# Altium schematic sheet TELECOM.SchDoc(TELECOM)
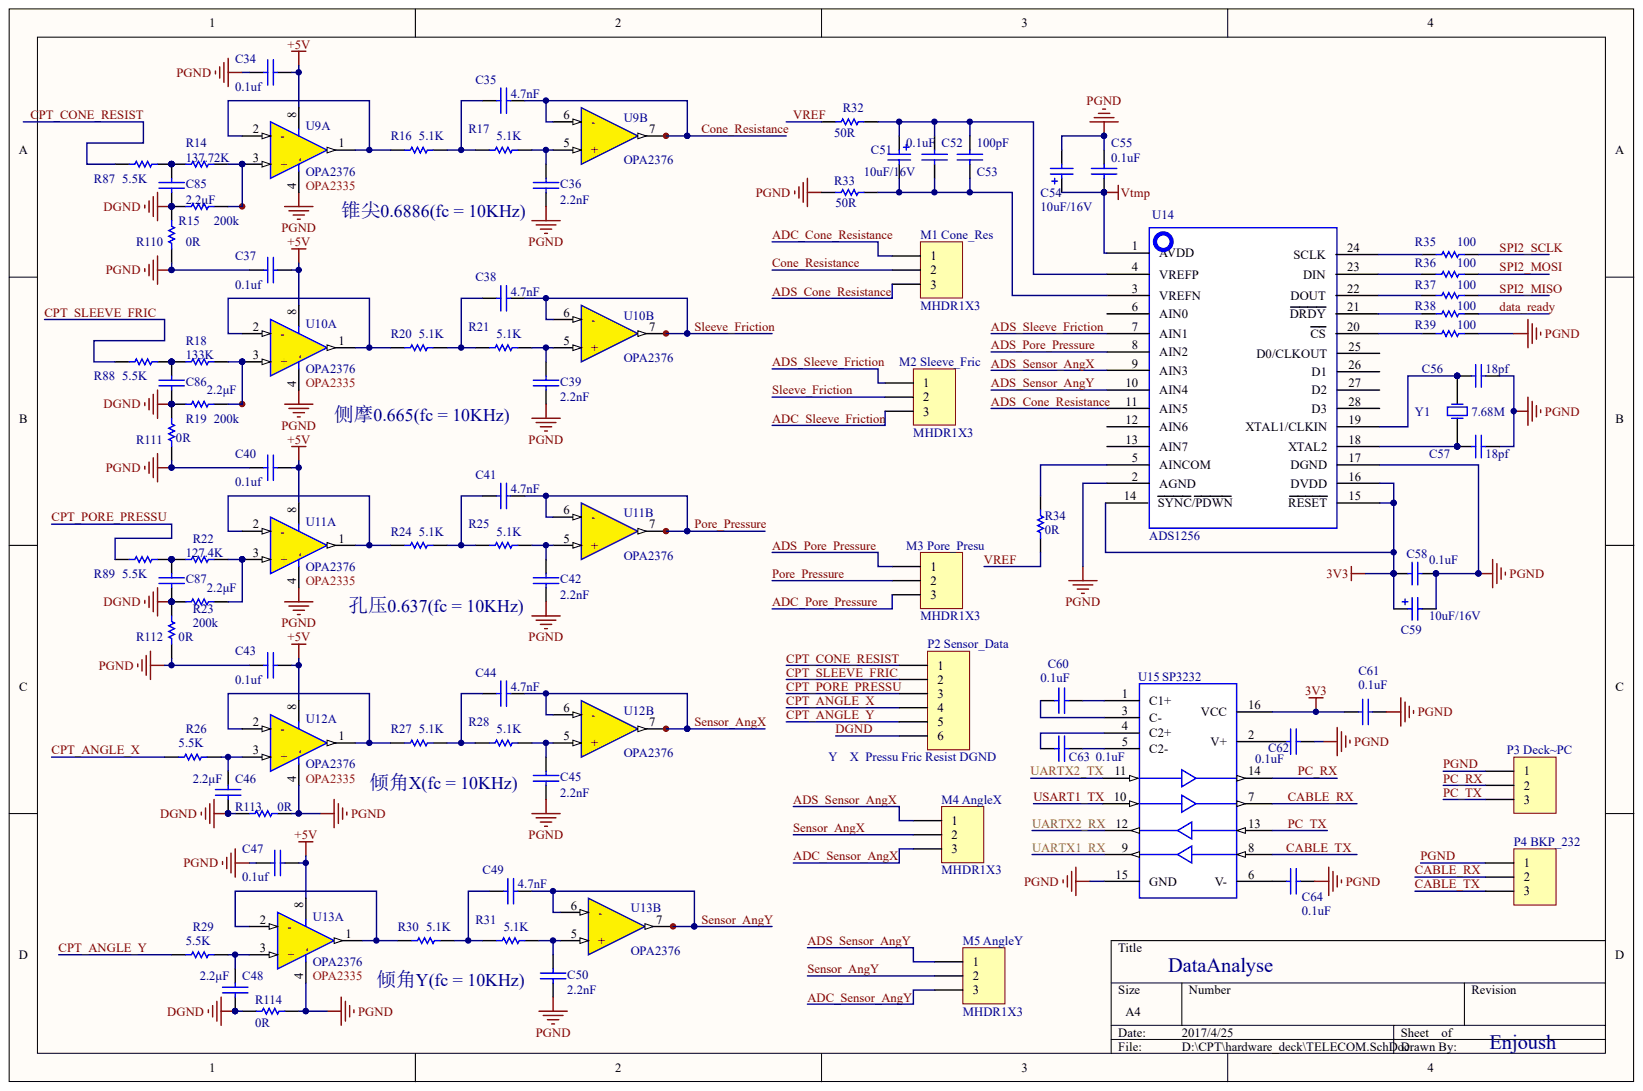
[diagram p0: TELECOM.SchDoc]

## Parts
C34  0.1uf
C35  4.7nF
C36  2.2nF
C37  0.1uf
C38  4.7nF
C39  2.2nF
C40  0.1uf
C41  4.7nF
C42  2.2nF
C43  0.1uf
C44  4.7nF
C45  2.2nF
C46  2.2μF
C47  0.1uf
C48  2.2μF
C49  4.7nF
C50  2.2nF
C51  10uF/16V
C52  0.1uF
C53  100pF
C54  10uF/16V
C55  0.1uF
C56  18pf
C57  18pf
C58  0.1uF
C59  10uF/16V
C60  0.1uF
C61  0.1uF
C62  0.1uF
C63  0.1uF
C64  0.1uF
C85  2.2μF
C86  2.2μF
C87  2.2μF
M1_Cone_Res  MHDR1X3
M2_Sleeve_Fric  MHDR1X3
M3_Pore_Presu  MHDR1X3
M4_AngleX  MHDR1X3
M5_AngleY  MHDR1X3
P2_Sensor_Data  Y    X  Pressu Fric Resist DGND
P3_Deck~PC  (no value)
P4_BKP_232  (no value)
R14  137.72K
R15  200k
R16  5.1K
R17  5.1K
R18  133K
R19  200k
R20  5.1K
R21  5.1K
R22  127.4K
R23  200k
R24  5.1K
R25  5.1K
R26  5.5K
R27  5.1K
R28  5.1K
R29  5.5K
R30  5.1K
R31  5.1K
R32  50R
R33  50R
R34  0R
R35  100
R36  100
R37  100
R38  100
R39  100
R87  5.5K
R88  5.5K
R89  5.5K
R110  0R
R111  0R
R112  0R
R113  0R
R114  0R
U9  OPA2376  (parts A,B)
U10  OPA2376  (parts A,B)
U11  OPA2376  (parts A,B)
U12  OPA2376  (parts A,B)
U13  OPA2376  (parts A,B)
U14  ADS1256
U15  SP3232
Y1  7.68M

## Nets
3V3: C58.1 C59.1 C61.1 U14.14(SYNC/PDWN) U14.15(RESET) U14.16(DVDD) U15.16(VCC)
+5V: C34.1 C37.1 C40.1 C43.1 C47.1 U9.8 U10.8 U11.8 U12.8 U13.8
VTMP: C54.1 C55.1 U14.1(AVDD)
ADC_CONE_RESISTANCE: M1_Cone_Res.1
ADC_PORE_PRESSURE: M3_Pore_Presu.3
ADC_SENSOR_ANGX: M4_AngleX.3
ADC_SENSOR_ANGY: M5_AngleY.3
ADC_SLEEVE_FRICTION: M2_Sleeve_Fric.3
ADS_CONE_RESISTANCE: M1_Cone_Res.3 U14.11(AIN5)
ADS_PORE_PRESSURE: M3_Pore_Presu.1 U14.8(AIN2)
ADS_SENSOR_ANGX: M4_AngleX.1 U14.9(AIN3)
ADS_SENSOR_ANGY: M5_AngleY.1 U14.10(AIN4)
ADS_SLEEVE_FRICTION: M2_Sleeve_Fric.1 U14.7(AIN1)
CABLE_RX: P4_BKP_232.2 U15.7
CABLE_TX: P4_BKP_232.3 U15.8
CONE_RESISTANCE: C35.2 M1_Cone_Res.2 U9.6(-) U9.7
CPT_ANGLE_X: P2_Sensor_Data.4 R26.1
CPT_ANGLE_Y: P2_Sensor_Data.5 R29.1
CPT_CONE_RESIST: P2_Sensor_Data.1 R87.1
CPT_PORE_PRESSU: P2_Sensor_Data.3 R89.1
CPT_SLEEVE_FRIC: P2_Sensor_Data.2 R88.1
DATA_READY: R38.1
DGND: C46.2 C48.2 C85.2 C86.2 C87.2 P2_Sensor_Data.6 R15.1 R19.1 R23.1 R110.2 R111.2 R112.2 R113.1 R114.2
PC_RX: P3_Deck~PC.2 U15.14
PC_TX: P3_Deck~PC.3 U15.13
PGND: C34.2 C36.2 C37.2 C39.2 C40.2 C42.2 C43.2 C45.2 C47.2 C50.2 C54.2 C55.2 C56.1 C57.1 C58.2 C59.2 C61.2 C62.2 C64.2 P3_Deck~PC.1 P4_BKP_232.1 R33.1 R39.1 R110.1 R111.1 R112.1 R113.2 R114.1 U9.4(-) U10.4(-) U11.4(-) U12.4(-) U13.4(-) U14.2(AGND) U14.17(DGND) U15.15(GND)
PORE_PRESSURE: C41.2 M3_Pore_Presu.2 U11.6(-) U11.7
SENSOR_ANGX: C44.2 M4_AngleX.2 U12.6(-) U12.7
SENSOR_ANGY: C49.2 M5_AngleY.2 U13.6(-) U13.7
SLEEVE_FRICTION: C38.2 M2_Sleeve_Fric.2 U10.6(-) U10.7
SPI2_MISO: R37.1
SPI2_MOSI: R36.1
SPI2_SCLK: R35.1
UARTX1_RX: U15.9
UARTX2_RX: U15.12
UARTX2_TX: U15.11
USART1_TX: U15.10
VREF: R32.1 R34.2
NetC35_1: C35.1 R16.2 R17.1
NetC36_1: C36.1 R17.2 U9.5(+)
NetC38_1: C38.1 R20.2 R21.1
NetC39_1: C39.1 R21.2 U10.5(+)
NetC41_1: C41.1 R24.2 R25.1
NetC42_1: C42.1 R25.2 U11.5(+)
NetC44_1: C44.1 R27.2 R28.1
NetC45_1: C45.1 R28.2 U12.5(+)
NetC46_1: C46.1 R26.2 U12.3(+)
NetC48_1: C48.1 R29.2 U13.3(+)
NetC49_1: C49.1 R30.2 R31.1
NetC50_1: C50.1 R31.2 U13.5(+)
NetC51_1: C51.1 C52.2 C53.2 R32.2 U14.4(VREFP)
NetC51_2: C51.2 C52.1 C53.1 R33.2 U14.3(VREFN)
NetC56_2: C56.2 U14.19(XTAL1/CLKIN) Y1.2
NetC57_2: C57.2 U14.18(XTAL2) Y1.1
NetC60_1: C60.1 U15.3(C-)
NetC60_2: C60.2 U15.1(C1+)
NetC62_1: C62.1 U15.2(V+)
NetC63_1: C63.1 U15.4(C2+)
NetC63_2: C63.2 U15.5(C2-)
NetC64_1: C64.1 U15.6(V-)
NetC85_1: C85.1 R14.1 R87.2
NetC86_1: C86.1 R18.1 R88.2
NetC87_1: C87.1 R22.1 R89.2
NetR14_2: R14.2 R15.2 U9.3(+)
NetR16_1: R16.1 U9.1 U9.2(-)
NetR18_2: R18.2 R19.2 U10.3(+)
NetR20_1: R20.1 U10.1 U10.2(-)
NetR22_2: R22.2 R23.2 U11.3(+)
NetR24_1: R24.1 U11.1 U11.2(-)
NetR27_1: R27.1 U12.1 U12.2(-)
NetR30_1: R30.1 U13.1 U13.2(-)
NetR34_1: R34.1 U14.5(AINCOM)
NetR35_2: R35.2 U14.24(SCLK)
NetR36_2: R36.2 U14.23(DIN)
NetR37_2: R37.2 U14.22(DOUT)
NetR38_2: R38.2 U14.21(DRDY)
NetR39_2: R39.2 U14.20(CS)

Not connected: U14 6(AIN0) 12(AIN6) 13(AIN7) 25(D0/CLKOUT) 26(D1) 27(D2) 28(D3)

## Library data of the parts
C56-C58 = (no symbol name): Value: 10pF; Published: 8-Jun-2000; LatestRevisionDate: 17-Jul-2002; LatestRevisionNote: Re-released for DXP Platform.; PackageReference: RAD-0.3; Publisher: Altium Limited
R14-R39 R87-R89 R110-R114 = (no symbol name): Value: 1K; Published: 8-Jun-2000; LatestRevisionDate: 17-Jul-2002; LatestRevisionNote: Re-released for DXP Platform.; PackageReference: AXIAL-0.5; Publisher: Altium Limited
C34-C55 C59-C64 C85-C87 M1_Cone_Res M2_Sleeve_Fric M3_Pore_Presu M4_AngleX M5_AngleY P2_Sensor_Data P3_Deck~PC P4_BKP_232 U9-U15 Y1 = (no symbol name)
  C51 C54 C59: Published: 8-Jun-2000; LatestRevisionDate: 17-Jul-2002; LatestRevisionNote: Re-released for DXP Platform.; PackageReference: POLAR0.8; Value: 10uF/16V
  M1_Cone_Res M2_Sleeve_Fric M3_Pore_Presu M4_AngleX M5_AngleY P2_Sensor_Data P3_Deck~PC P4_BKP_232: LatestRevisionDate: 17-Jul-2002; LatestRevisionNote: Re-released for DXP Platform.
  Y1: Published: 8-Jun-2000; LatestRevisionDate: 17-Jul-2002; LatestRevisionNote: Re-released for DXP Platform.; PackageReference: R38
  C51 C54 C59 M1_Cone_Res M2_Sleeve_Fric M3_Pore_Presu M4_AngleX M5_AngleY P2_Sensor_Data P3_Deck~PC P4_BKP_232 Y1: Publisher: Altium Limited
